# Altium schematic sheet ETH.SchDoc
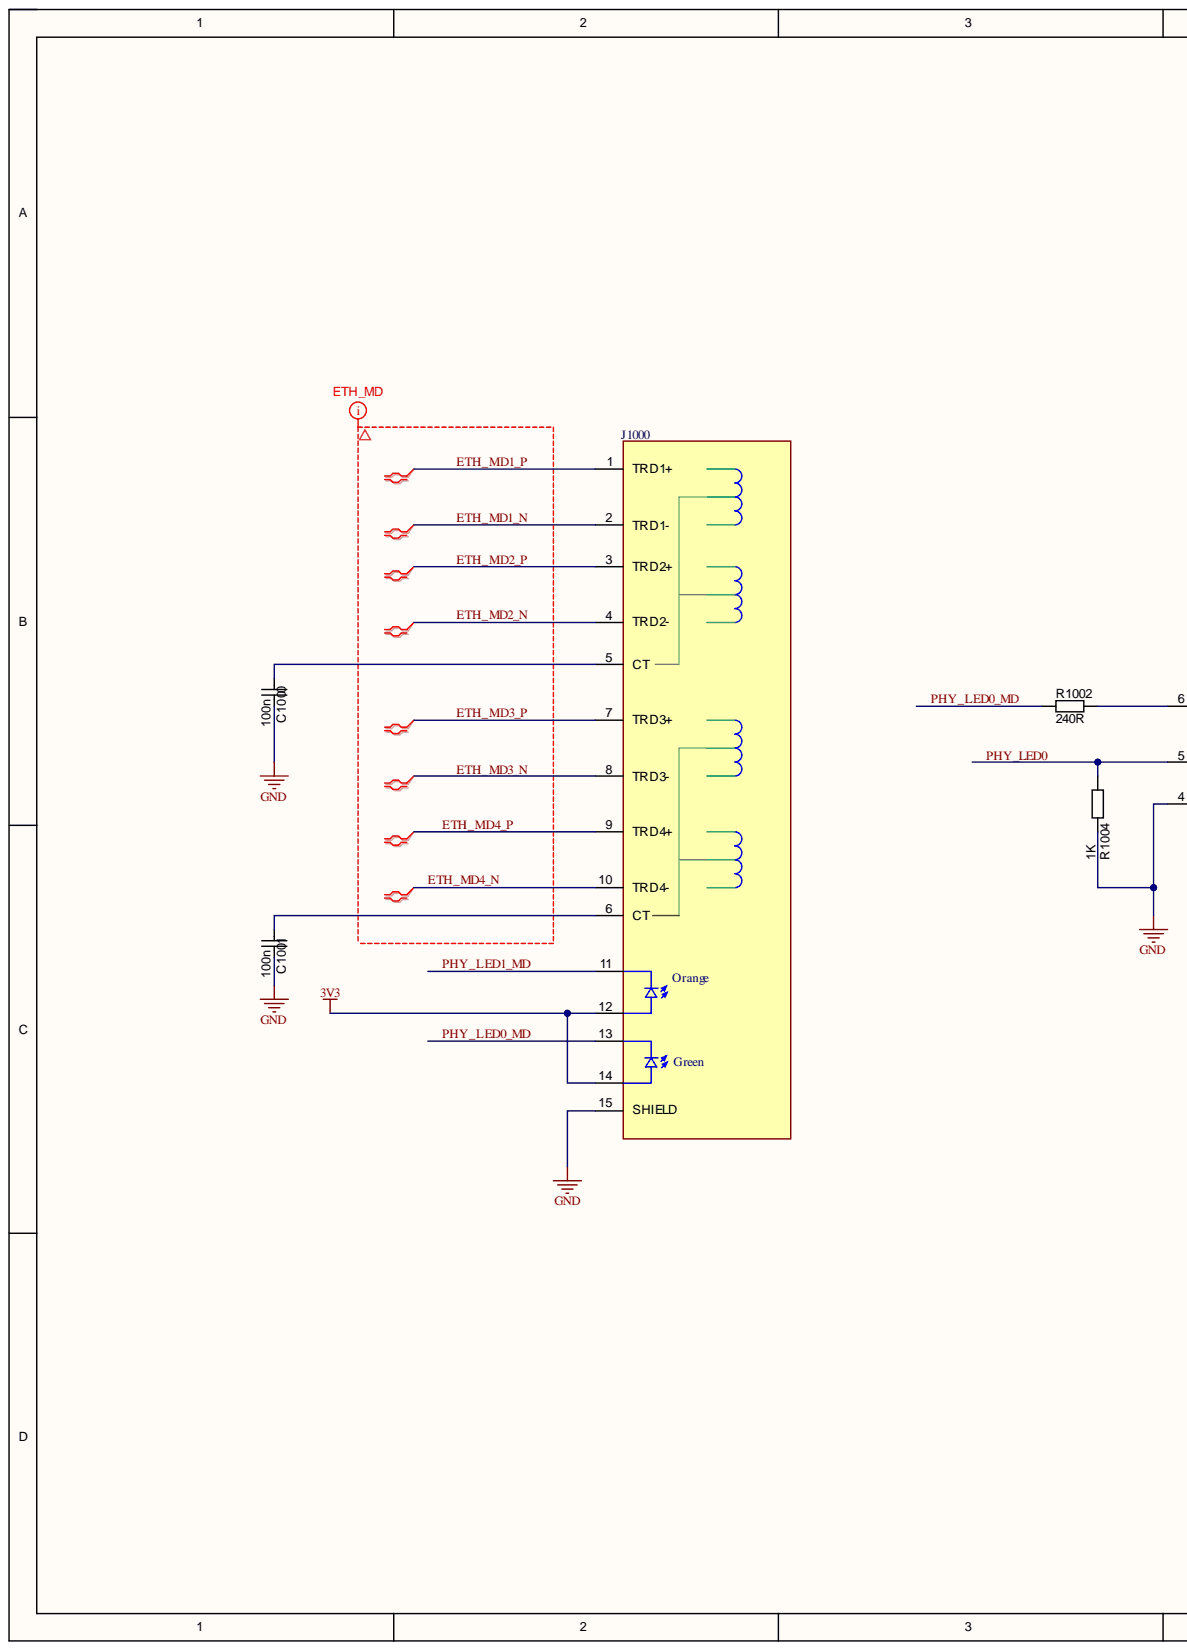
[diagram p0: ETH.SchDoc, left]
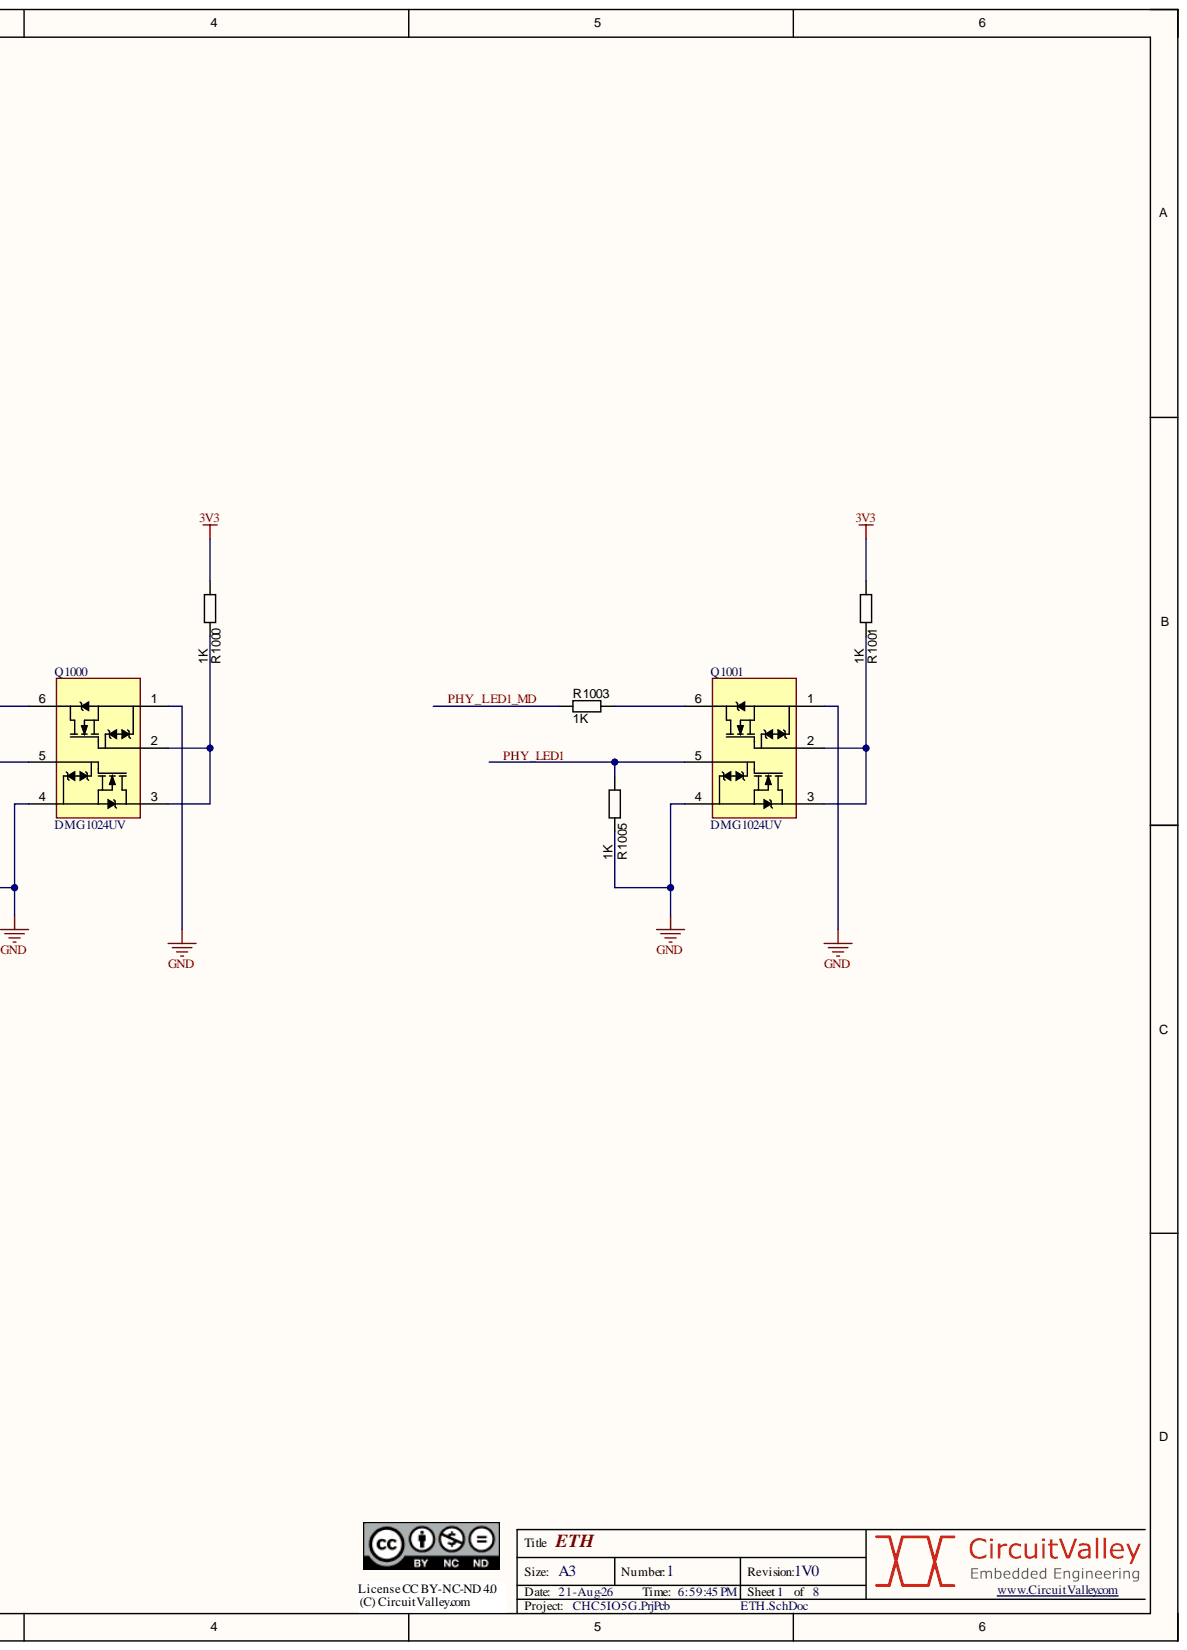
[diagram p1: ETH.SchDoc, right]

## Parts
C1000  100n  [CAPC1005N]
C1001  100n  [CAPC1005N]
J1000  HR963380AE  [HR963380AE]  (value not drawn)
Q1000  DMG1024UV  [SOT563]
Q1001  DMG1024UV  [SOT563]
R1000  value 1K  [RESC1005N]  (hidden comment: Thick Film)
R1001  value 1K  [RESC1005N]  (hidden comment: Thick Film)
R1002  value 240R  [RESC1005N]  (hidden comment: Thick Film)
R1003  value 1K  [RESC1005N]  (hidden comment: Thick Film)
R1004  value 1K  [RESC1005N]  (hidden comment: Thick Film)
R1005  value 1K  [RESC1005N]  (hidden comment: Thick Film)

## Nets
3V3: J1000.12 J1000.14 R1000.1 R1001.1
GND: C1000.1 C1001.1 J1000.15(SHIELD) Q1000.1 Q1000.4 Q1001.1 Q1001.4 R1004.2 R1005.2
ETH_MD1_N: J1000.2(TRD1-)
ETH_MD1_P: J1000.1(TRD1+)
ETH_MD2_N: J1000.4(TRD2-)
ETH_MD2_P: J1000.3(TRD2+)
ETH_MD3_N: J1000.8(TRD3-)
ETH_MD3_P: J1000.7(TRD3+)
ETH_MD4_N: J1000.10(TRD4-)
ETH_MD4_P: J1000.9(TRD4+)
PHY_LED0: Q1000.5 R1004.1
PHY_LED0_MD: J1000.13 R1002.1
PHY_LED1: Q1001.5 R1005.1
PHY_LED1_MD: J1000.11 R1003.1
NetC1000_2: C1000.2 J1000.5(CT)
NetC1001_2: C1001.2 J1000.6(CT)
NetQ1000_2: Q1000.2 Q1000.3 R1000.2
NetQ1000_6: Q1000.6 R1002.2
NetQ1001_2: Q1001.2 Q1001.3 R1001.2
NetQ1001_6: Q1001.6 R1003.2

## Library data of the parts
C1000 C1001 = CAPC_10n_0402_16V_10%: Capacitor SMD Cer 10nF 10% 16V X7R 0402; Manufacturer 1: AVX Corporation; Manufacturer Part Number 1: 0402YC103KAT2A; Ratings: 16V; X7R; Component Kind: Standard; ComponentLink1Description: http://www.avx.com/docs/Catalogs/cx7r.pdf; ComponentLink1URL: http://www.avx.com/docs/Catalogs/cx7r.pdf; ComponentLink2Description: http://www.yageo.com/documents/recent/UPY-GPHC_X7R_6.3V-to-50V_8.pdf; ComponentLink2URL: http://www.yageo.com/documents/recent/UPY-GPHC_X7R_6.3V-to-50V_8.pdf; Library Name: PBL - Capacitors.SCHLIB; Manufacturer 2: Yageo; Manufacturer Part Number 2: CC0402KRX7R7BB103; Operating Temperature: -55°C ~ 125°C; Package / Case: 0402 (1005 Metric); Size / Dimension: 0.039" L x 0.020" W (1.00mm x 0.50mm); Supplier 1: Digi-Key; Supplier 2: Digi-Key; Supplier Part Number 1: 478-1114-1-ND; Supplier Part Number 2: 311-1042-1-ND; Tolerance: ±10%; Value: 100n
J1000 = HR963380AE: HR963380AE MagJack 1000BASE-T | 1X1 | 3w-OG/Y | Tab Up | Low Profile | RoHS; MF: Bel Fuse; MP: L829-1J1T-43; Availability: Unavailable; Component Kind: Standard; Package: None; Price: None; SnapEDA_Link: https://www.snapeda.com/parts/L829-1J1T-43/Bel%20Fuse%20Inc./view-part/562341/?ref=snap; Library Name: cvlib.SchLib
R1000-R1005 = RES_3K3_0402_5%: Resistor SMD chip 3.3k Ohm 0.063W 5% 0402; Manufacturer 1: Yageo; Manufacturer Part Number 1: RC0402JR-073K3L; Ratings: 1/16 W; Component Kind: Standard; ComponentLink1Description: http://www.yageo.com/documents/recent/PYu-RC0402_51_RoHS_L_6.pdf; ComponentLink1URL: http://www.yageo.com/documents/recent/PYu-RC0402_51_RoHS_L_6.pdf; Library Name: PBL - Resistors.SCHLIB; Material: Thick Film; Package / Case: 0402 (1005 metric); Supplier 1: Digi-Key; Supplier Part Number 1: 311-3.3KJRCT-ND; Tolerance: ±5%
Q1000 Q1001 = DMG1024UV: Component Kind: Standard; Description: DMG1024UV; Library Name: cvlib.SchLib
